# Altium schematic sheet USB.SchDoc
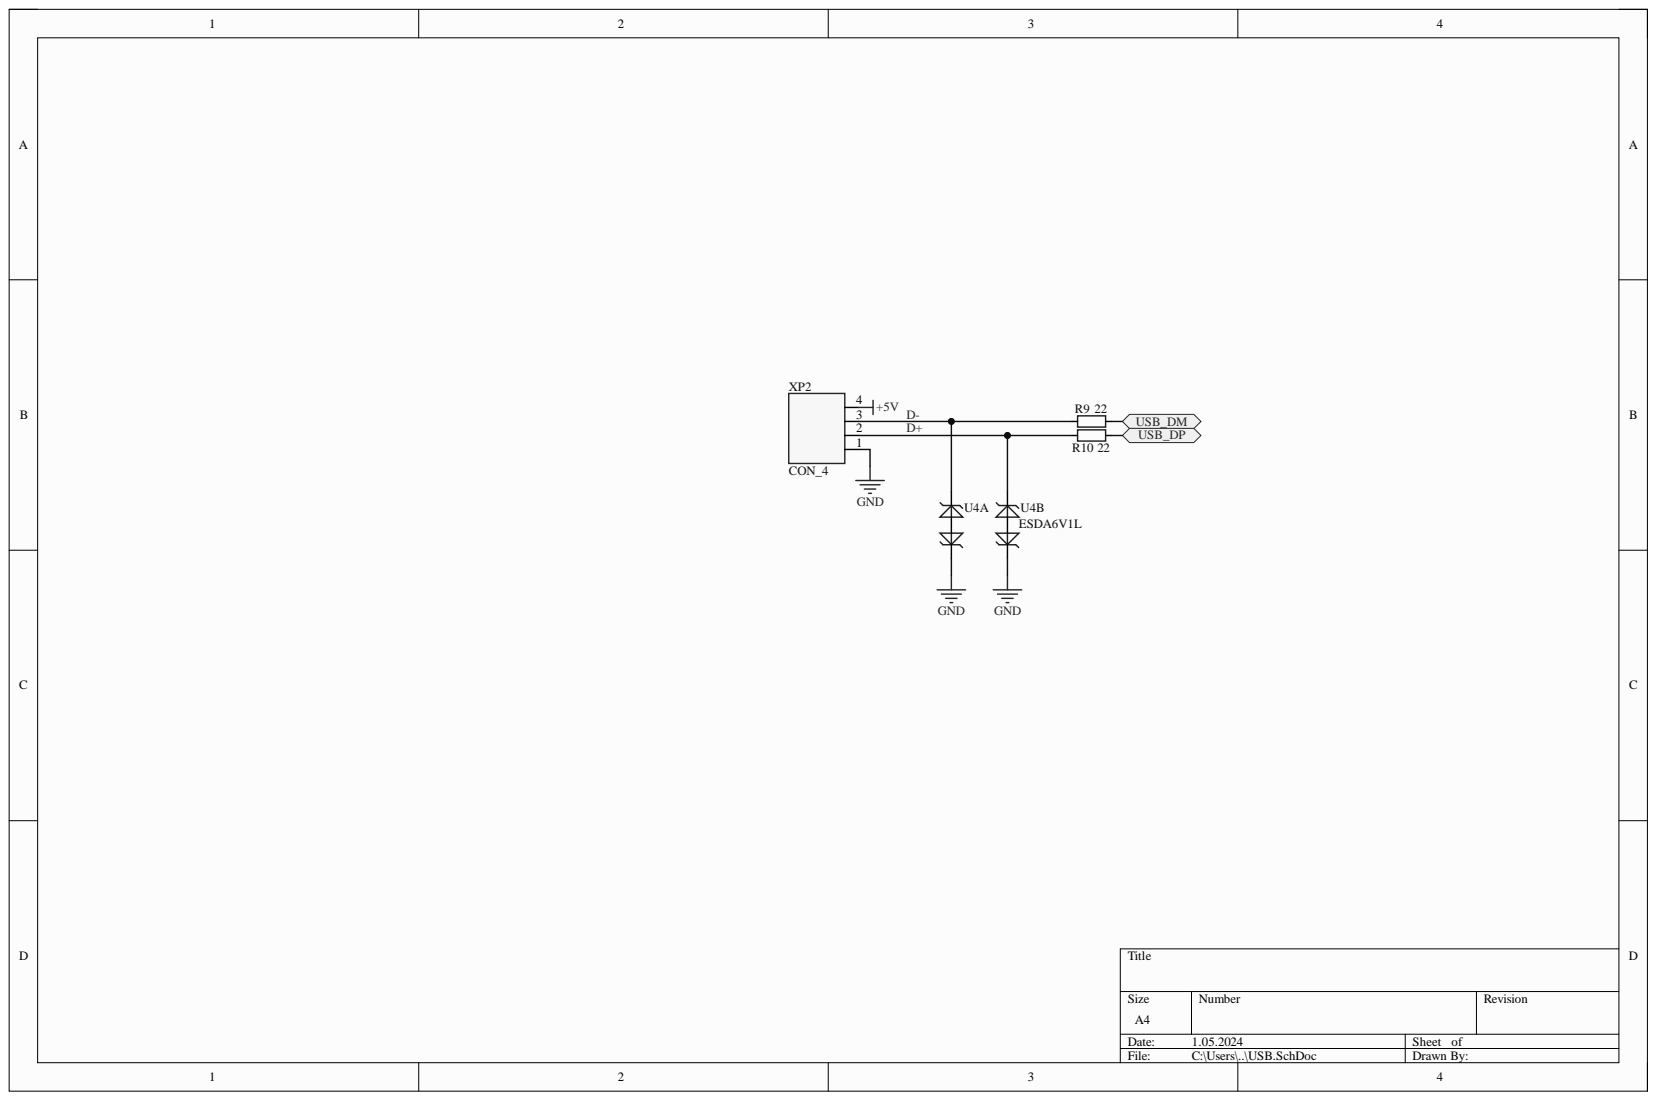
[diagram p0: USB.SchDoc]

## Parts
R9  22  [SMD_0603]
R10  22  [SMD_0603]
U4  ESDA6V1L  [SOT23-3]  (parts A,B)
XP2  CON_4  [HEAD-SMD-2.54/4]

## Ports
USB_DM, USB_DP

## Nets
+5V: XP2.4
GND: U4.3 XP2.1
D+: R10.2 U4.2 XP2.2
D-: R9.2 U4.1 XP2.3
USB_DM: R9.1 ; port USB_DM
USB_DP: R10.1 ; port USB_DP

## Library data of the parts
R9 R10 = RES: Resistor; Component Kind: Standard; Library Name: DISCRETE.SchLib; Value: 22
U4 = CDSOT23-SM712: Component Kind: Standard; Library Name: ICs.SchLib; Value: ESDA6V1L
XP2 = CON_4: Component Kind: Standard; Library Name: Connectors.SchLib
